# Altium schematic sheet Display.SchDoc
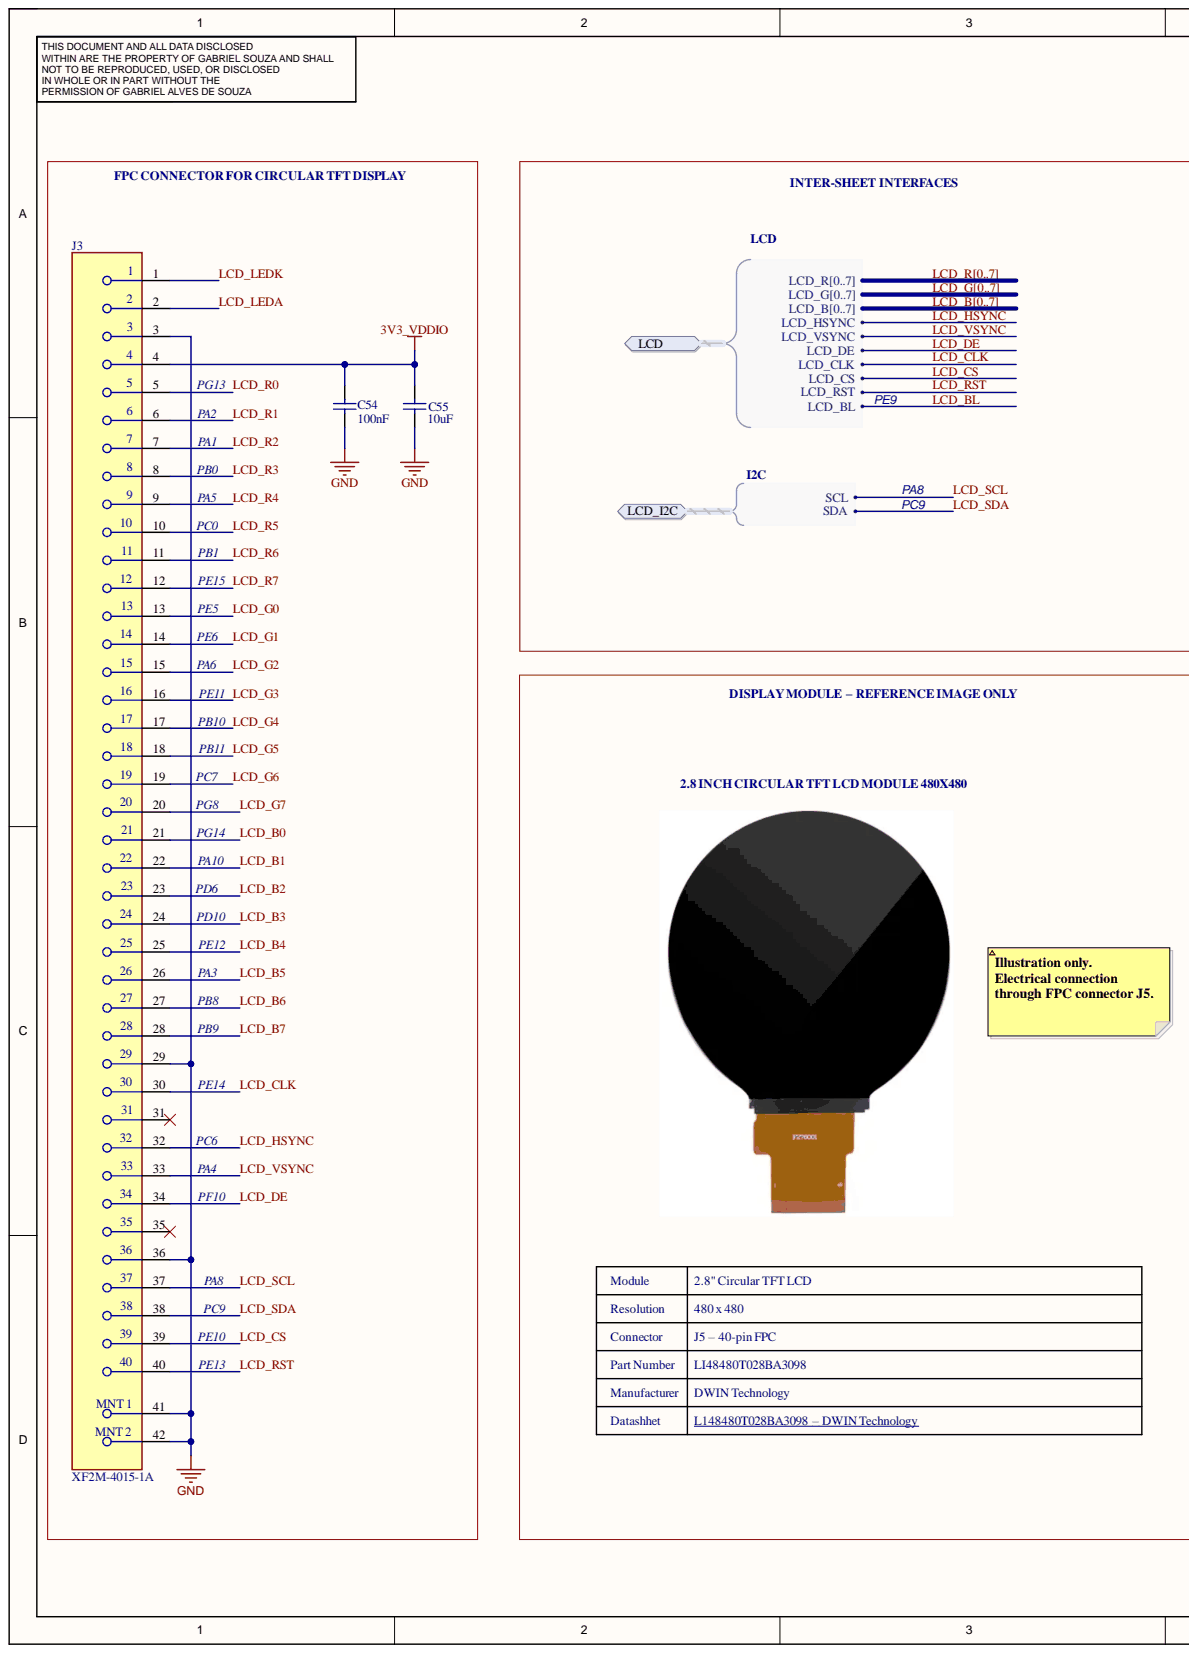
[diagram p0: Display.SchDoc, left]
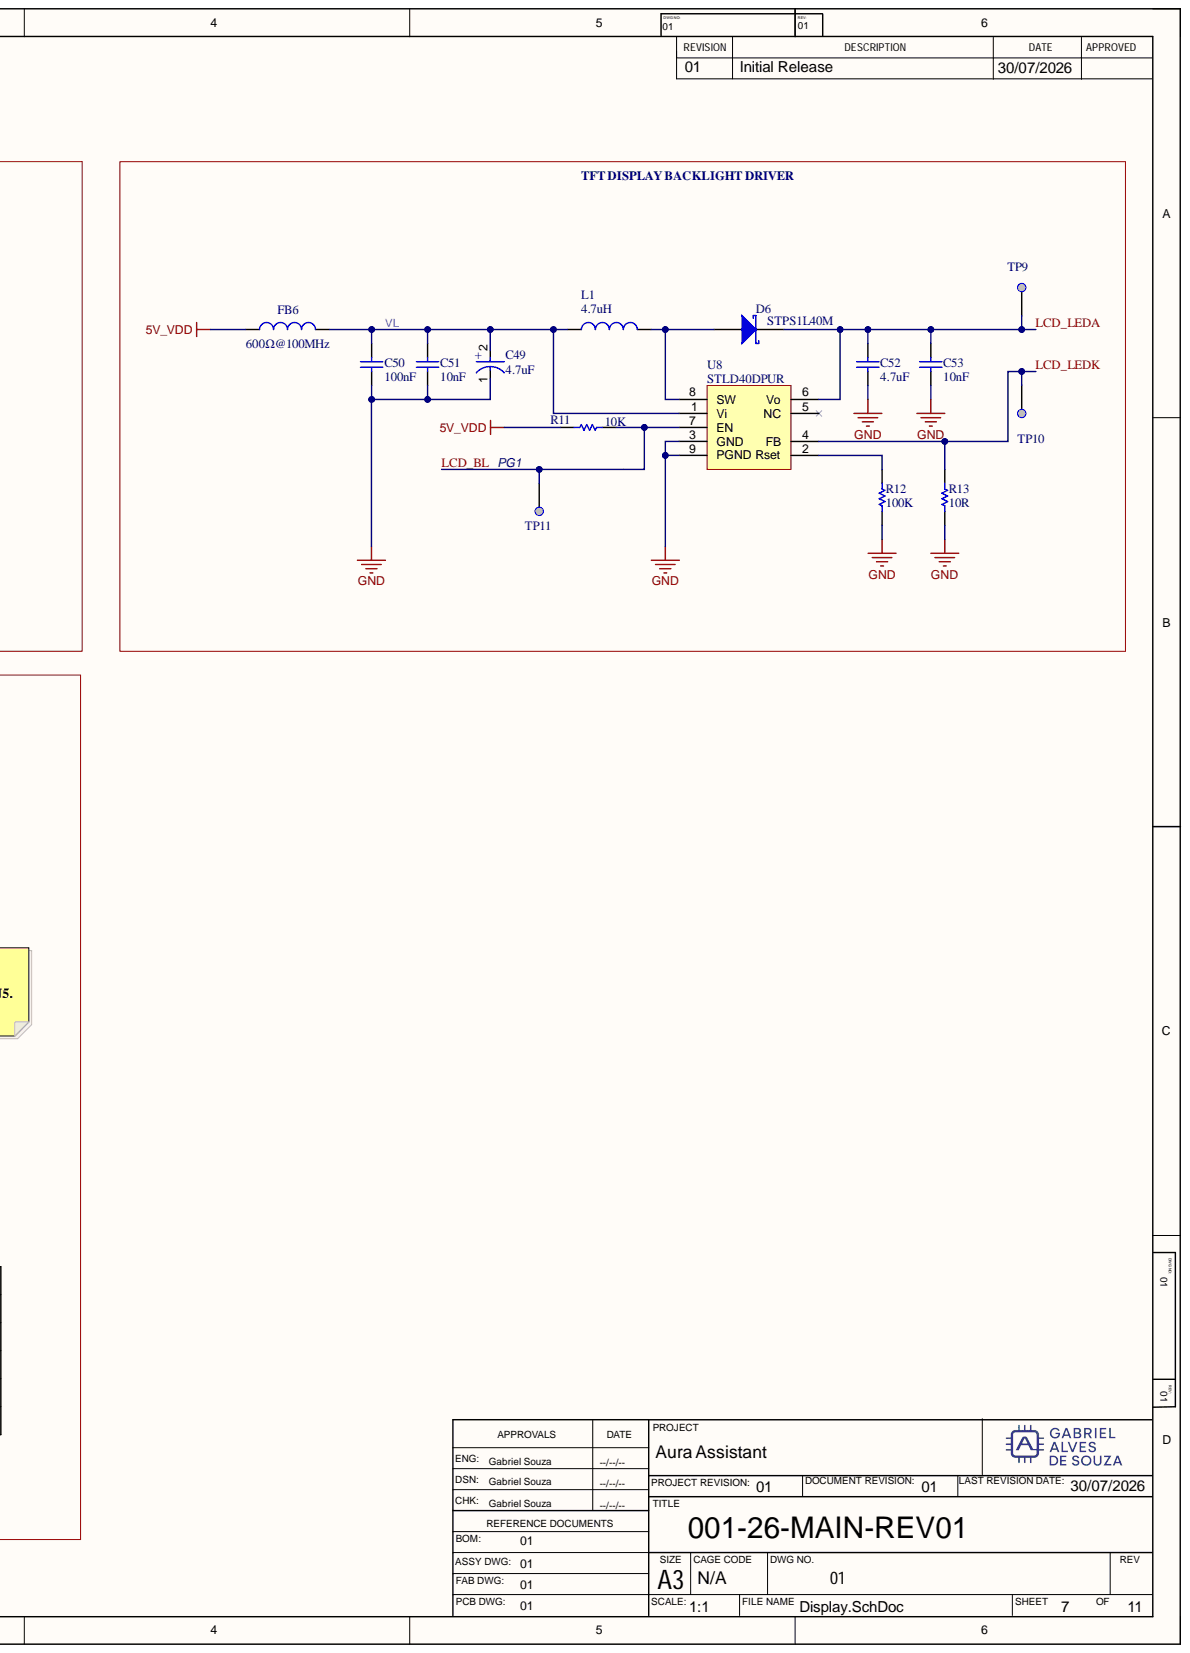
[diagram p1: Display.SchDoc, right]

## Parts
C49  Capacitance: 4.7uF  [FP-T491A225K010AT-MFG]  (hidden comment: TAJA475K016RNJ)
C50  value 100nF  [C_0402_IPC_N]  (hidden comment: Capacitor)
C51  value 10nF  [C_0603_IPC_N]  (hidden comment: Capacitor)
C52  value 4.7uF  [C_1206_IPC_N]  (hidden comment: Capacitor)
C53  value 10nF  [C_0603_IPC_N]  (hidden comment: Capacitor)
C54  value 100nF  [C_0402_IPC_N]  (hidden comment: Capacitor)
C55  value 10uF  [C_0805_IPC_N]  (hidden comment: Capacitor)
D6  STPS1L40M  [DIO_DO216-AA]
FB6  value 600Ω@100MHz  [L_0603_IPC_N]  (hidden comment: Inductor)
J3  XF2M-4015-1A  [FP-XF2M-4015-1A-MFG]
L1  value 4.7uH  [L1210_LQH]  (hidden comment: Inductor)
R11  value 10K  [R_0603_IPC_N]  (hidden comment: Resistor)
R12  value 100K  [R_0603_IPC_N]  (hidden comment: Resistor)
R13  value 10R  [R_0805_IPC_N]  (hidden comment: Resistor)
TP9  Test Point  [TP_SMD_2MM]  (value not drawn)  (no BOM)
TP10  Test Point  [TP_SMD_2MM]  (value not drawn)  (no BOM)
TP11  Test Point  [TP_SMD_2MM]  (value not drawn)  (no BOM)
U8  STLD40DPUR  [ICC_QFN8-EPAD_3X3_P065]

## Ports
LCD, LCD.LCD_B0, LCD.LCD_B1, LCD.LCD_B2, LCD.LCD_B3, LCD.LCD_B4, LCD.LCD_B5, LCD.LCD_B6, LCD.LCD_B7, LCD.LCD_B[0..7], LCD.LCD_BL, LCD.LCD_CLK, LCD.LCD_CS, LCD.LCD_DE, LCD.LCD_G0, LCD.LCD_G1, LCD.LCD_G2, LCD.LCD_G3, LCD.LCD_G4, LCD.LCD_G5, LCD.LCD_G6, LCD.LCD_G7, LCD.LCD_G[0..7], LCD.LCD_HSYNC, LCD.LCD_R0, LCD.LCD_R1, LCD.LCD_R2, LCD.LCD_R3, LCD.LCD_R4, LCD.LCD_R5, LCD.LCD_R6, LCD.LCD_R7, LCD.LCD_R[0..7], LCD.LCD_RST, LCD.LCD_VSYNC, LCD_I2C, LCD_I2C.SCL, LCD_I2C.SDA

## Nets
3V3_VDDIO: C54.2 C55.2 J3.4
5V_VDD: FB6.1 R11.2
GND: C49.1 C50.1 C51.1 C52.1 C53.1 C54.1 C55.1 J3.3 J3.29 J3.36 J3.41 J3.42 R12.2 R13.2 U8.3(GND) U8.9(PGND)
LCD_B0: J3.21 ; port LCD  [also labelled LCD_B]
LCD_B1: J3.22 ; port LCD  [also labelled LCD_B]
LCD_B2: J3.23 ; port LCD  [also labelled LCD_B]
LCD_B3: J3.24 ; port LCD  [also labelled LCD_B]
LCD_B4: J3.25 ; port LCD  [also labelled LCD_B]
LCD_B5: J3.26 ; port LCD  [also labelled LCD_B]
LCD_B6: J3.27 ; port LCD  [also labelled LCD_B]
LCD_B7: J3.28 ; port LCD  [also labelled LCD_B]
LCD_BL: R11.1 TP11.1 U8.7(EN) ; port LCD
LCD_CLK: J3.30 ; port LCD
LCD_CS: J3.39 ; port LCD
LCD_DE: J3.34 ; port LCD
LCD_G0: J3.13 ; port LCD  [also labelled LCD_G]
LCD_G1: J3.14 ; port LCD  [also labelled LCD_G]
LCD_G2: J3.15 ; port LCD  [also labelled LCD_G]
LCD_G3: J3.16 ; port LCD  [also labelled LCD_G]
LCD_G4: J3.17 ; port LCD  [also labelled LCD_G]
LCD_G5: J3.18 ; port LCD  [also labelled LCD_G]
LCD_G6: J3.19 ; port LCD  [also labelled LCD_G]
LCD_G7: J3.20 ; port LCD  [also labelled LCD_G]
LCD_HSYNC: J3.32 ; port LCD
LCD_LEDA: C52.2 C53.2 D6.2 J3.2 TP9.1 U8.6(Vo)
LCD_LEDK: J3.1 R13.1 TP10.1 U8.4(FB)
LCD_R0: J3.5 ; port LCD  [also labelled LCD_R]
LCD_R1: J3.6 ; port LCD  [also labelled LCD_R]
LCD_R2: J3.7 ; port LCD  [also labelled LCD_R]
LCD_R3: J3.8 ; port LCD  [also labelled LCD_R]
LCD_R4: J3.9 ; port LCD  [also labelled LCD_R]
LCD_R5: J3.10 ; port LCD  [also labelled LCD_R]
LCD_R6: J3.11 ; port LCD  [also labelled LCD_R]
LCD_R7: J3.12 ; port LCD  [also labelled LCD_R]
LCD_RST: J3.40 ; port LCD
LCD_SCL: J3.37 ; port LCD_I2C
LCD_SDA: J3.38 ; port LCD_I2C
LCD_VSYNC: J3.33 ; port LCD
VL: C49.2 C50.2 C51.2 FB6.2 L1.1 U8.1(Vi)
NetD6_1: D6.1 L1.2 U8.8(SW)
NetR12_1: R12.1 U8.2(Rset)

Not connected: J3 31 35; U8 5(NC)

## Library data of the parts
C49 = CMP-03017-000061-1: CAP TANT 4.7uF ±10% 16V CASE-A-3216-18(mm); Dielectric Material: Tantalum; Dissipation Factor: 6%; ESR (Equivalent Series Resistance): 8R; Leakage Current: 500nA; Life (Hours): 2000Hour; Polarity: Polar; Ripple Current: 97mA; Voltage: 10V; Case Code (Imperial): 1206; Case Code (Metric): 3216; Case/Package: 1206; Component Kind: Standard; Depth: 1.6mm; Features: General Purpose; Height: 1.6mm; Height - Seated (Max): 0.071inch; Lead Free: Lead Free; Length: 3.2mm; Library Name: Altium Content Vault; Max Operating Temperature: 125°C; Min Operating Temperature: -55°C; Mount: Surface Mount; Number of Pins: 2; Order_Code_LCSC: C7187; Package Quantity: 1; Packaging: Tape and Reel; Radiation Hardening: No; REACH SVHC: No SVHC; RoHS Compliant: Yes; Termination: SMD/SMT; Tolerance: 10%; Voltage Rating: 10V; Voltage Rating (DC): 10V; Width: 1.6mm
C50 C54 = CAP: Capacitor, MLCC, 100nF, 16V, X7R, +/-10%, 0402, T=0,5mm; Manufacturer: TDK; Manufacturer_Part_Number: C1005X7R1C104KT000F; Component Kind: Standard; ComponentLink1Description: Datasheet; ComponentLink1URL: https://www.lcsc.com/datasheet/C338032.pdf?spm=wm.sxq.inf.ggs___wm.fly.bg.6.xh&lcsc_vid=TlIKVlcFRVJWVl1WQVFfBlQDRFgPAVVQRFhaBQIFFgQxVlNeRVRbU1RQQ1dWUTtW; Order_Code_LCSC: C338032; Package: 0402; Library Name: Gabriel_Lib.IntLib
C51 C53 = CAP: Capacitor, MLCC, 10nF, 50V, X7R, +/-10%, 0603, T=0,5mm; Manufacturer: TDK; Manufacturer_Part_Number: CGA3E2X7R1H103KT0Y0N; Component Kind: Standard; ComponentLink1Description: Datasheet; ComponentLink1URL: https://www.lcsc.com/datasheet/C338032.pdf?spm=wm.sxq.inf.ggs___wm.fly.bg.6.xh&lcsc_vid=TlIKVlcFRVJWVl1WQVFfBlQDRFgPAVVQRFhaBQIFFgQxVlNeRVRbU1RQQ1dWUTtW; Order_Code_LCSC: C342901; Package: 0603; Library Name: Gabriel_Lib.IntLib
C52 = CAP: Capacitor, MLCC, 4.7uF, 50V, X7R, +/-10%, 1206, T=0,5mm; Manufacturer: muRata; Manufacturer_Part_Number: GRM31CR71H475KA12L; Component Kind: Standard; ComponentLink1Description: Datasheet; ComponentLink1URL: https://www.lcsc.com/datasheet/C338032.pdf?spm=wm.sxq.inf.ggs___wm.fly.bg.6.xh&lcsc_vid=TlIKVlcFRVJWVl1WQVFfBlQDRFgPAVVQRFhaBQIFFgQxVlNeRVRbU1RQQ1dWUTtW; Order_Code_LCSC: C77096; Package: 1206; Library Name: Gabriel_Lib.IntLib
C55 = CAP: Capacitor, MLCC, 10uF, 16V, X5R, +/-10%, 0805, T=0,5mm; Manufacturer: muRata; Manufacturer_Part_Number: GRM21BR61C106KE15K; Component Kind: Standard; ComponentLink1Description: Datasheet; ComponentLink1URL: https://www.lcsc.com/datasheet/C338032.pdf?spm=wm.sxq.inf.ggs___wm.fly.bg.6.xh&lcsc_vid=TlIKVlcFRVJWVl1WQVFfBlQDRFgPAVVQRFhaBQIFFgQxVlNeRVRbU1RQQ1dWUTtW; Order_Code_LCSC: C408141; Package: 0805; Library Name: Gabriel_Lib.IntLib
D6 = MCD-00033: Low Drop power Schottky rectifier(1A, 40V, 0.4V); BMS_Part_Number: 9151085; Manufacturer: STMICROELECTRONICS; Manufacturer_HQ_COO: EU; Part_Preference: Forbidden; Part_Type: Diode; Component_Country_Of_Origin: China; Database Table Name: MCD_PARTS$; ECCN_EU: NEC; ECCN_US: EAR99; ID: 2485; Initial_Board_Reference: MB1274; Order_Code_RS: 189-4505; Provided_By: Subcon; ShowFields: YES; Component Kind: Standard; ComponentLink1Description: STM_Diode_STPS1L40M_DO216-AA.pdf; ComponentLink1URL: http://tunmcdsvn.tun.st.com/Component_Documentation_Library/Trunk/Diode/STMICROELECTRONICS_STPS1L40M; Internal_Part_Number: MCD-00033; Library Name: MCD_PARTS_database.DbLib; Manufacturer_Part_Number: STPS1L40M; Order_Code_Digikey: 497-3212-2-ND; Order_Code_Farnell: 2629693; Order_Code_LCSC: C155572; Package: DO216-AA; Status: Released; Value: STPS1L40M
FB6 = Inductor: FERRITE BEAD 600Ω 0603 1LN; Manufacturer: TAI-TECH; Manufacturer_Part_Number: FCM1608KF-601T03; Component Kind: Standard; ComponentLink1URL: http://tunmcdsvn.tun.st.com/Component_Documentation_Library/Trunk/Inductor/MURATA_DFE201612P_Series/; Order_Code_LCSC: C141723; Part_Type: Inductor; Library Name: Gabriel_Lib.IntLib
J3 = CMP-2000-07422-2: CONN FPC 40POS 0.50MM R/A; Actuator Material: Polymer; Contact Resistance: 50mR; Current Rating: 500mA; Gender: Receptacle; Insulation Resistance: 100MR; Max Voltage Rating (AC): 50V; Number of Contacts: 40; Pitch: 0.5mm; Component Kind: Standard; Contact Material: Copper Alloy; Contact Plating: Gold; Depth: 6.2mm; Flammability Rating: UL94 V-0; Housing Color: Natural; Housing Material: Polymer; Lead Free: Lead Free; Lead Pitch: 0.5mm; Length: 24.1mm; Library Name: Altium Content Vault; Max Current Rating: 500mA; Max Operating Temperature: 85°C; Max Voltage Rating (DC): 50V; Min Operating Temperature: -30°C; Mount: Surface Mount; Number of Poles: 40; Number of Positions: 40; Number of Rows: 1; Order_Code_LCSC: C225713; Orientation: Right Angle; Package Quantity: 1; Packaging: Tape and Reel; REACH SVHC: No SVHC; RoHS Compliant: Yes; Series: XF2M; Termination: Solder; Voltage Rating: 50V; Voltage Rating (AC): 50V; Voltage Rating (DC): 50V
L1 = MCD-00048: FIXED IND 4.7UH 1A 180 MOHM 30% SMD; Manufacturer: MURATA; Manufacturer_Part_Number: LQH32PN4R7NN0L; Part_Preference: Recommended; Component_Country_Of_Origin: Japan°°; Database Table Name: MCD_PARTS$; ID: 2544; Initial_Board_Reference: MB1274; Order_Code_RS: 2470296; Provided_By: Subcon; ShowFields: YES; Component Kind: Standard; ComponentLink1Description: Murata_Inductor_LQH32PN4R7NN0L.pdf; ComponentLink1URL: http://tunmcdsvn.tun.st.com/Component_Documentation_Library/Trunk/Inductor/MURATA_LQH32PN4R7NN0; ECCN_EU: n/a; ECCN_US: n/a; Internal_Part_Number: MCD-00048; Library Name: MCD_PARTS_database.DbLib; Order_Code_Digikey: 490-5338-2-ND; Order_Code_Farnell: 2470296; Order_Code_LCSC: C98021; Package: 1210; Part_Type: Inductor; Status: Released
R11 = RES: Resistor, 10K, 0603, 0.1%, 0.1W; Manufacturer: UNI-ROYAL; Manufacturer_Part_Number: TC0350B1002T5F; Component Kind: Standard; ComponentLink1Description: Datasheet; Order_Code_LCSC: C2989264; Package: 0603; Part_Type: Resistor; Library Name: Gabriel_Lib.IntLib
R12 = RES: Resistor, 100K, 0603, 0.1%, 100mW; Manufacturer: YAGEO; Manufacturer_Part_Number: RT0603BRD07100KL; Component Kind: Standard; ComponentLink1Description: Datasheet; Order_Code_LCSC: C122538; Package: 0603; Part_Type: Resistor; Library Name: Gabriel_Lib.IntLib
R13 = RES: Resistor, 10R, 0805, 0.1%,  250mW; Manufacturer: KOA; Manufacturer_Part_Number: SG73S2ATTD10R0F; Component Kind: Standard; ComponentLink1Description: Datasheet; Order_Code_LCSC: C160107; Package: 0805; Part_Type: Resistor; Library Name: Gabriel_Lib.IntLib
TP9-TP11 = MCD-00177: Test Point, SMD, 2mm; Part_Preference: Recommended; Part_Type: Misc; Component_Country_Of_Origin: Unknown; Database Table Name: MCD_PARTS$; ID: 2690; ShowFields: YES; ComponentLink1URL: http://tunmcdsvn.tun.st.com/Component_Documentation_Library/Trunk/Misc; Internal_Part_Number: MCD-00177; Library Name: MCD_PARTS_database.DbLib; Manufacturer: N/A; Manufacturer_Part_Number: N/A; Order_Code_Digikey: N/A; Order_Code_Farnell: N/A; Order_Code_RS: N/A; Status: Released; Value: N/A
U8 = MCD-00082: White LED power supply for large display backlight, QFN8; BMS_Part_Number: 9176009; Manufacturer: STMICROELECTRONICS; Manufacturer_HQ_COO: EU; Part_Preference: Recommended; Part_Type: IC; Component_Country_Of_Origin: Malaysia; Database Table Name: MCD_PARTS$; ECCN_EU: NEC; ECCN_US: EAR99; ID: 2510; Initial_Board_Reference: MB1274; Provided_By: Subcon; ShowFields: YES; Component Kind: Standard; ComponentLink1Description: STM_White-LED-PWR_STLD40DPUR_QFN8.pdf; ComponentLink1URL: http://tunmcdsvn.tun.st.com/Component_Documentation_Library/Trunk/IC/STMICROELECTRONICS_STLD40DPUR; Internal_Part_Number: MCD-00082; Library Name: MCD_PARTS_database.DbLib; Manufacturer_Part_Number: STLD40DPUR; Order_Code_Digikey: 497-8927-2-ND; Order_Code_Farnell: 3129823; Order_Code_LCSC: C2969763; Package: QFN-8; Status: Released; Value: STLD40DPUR
